# Altium schematic sheet Button.SchDoc(Button)
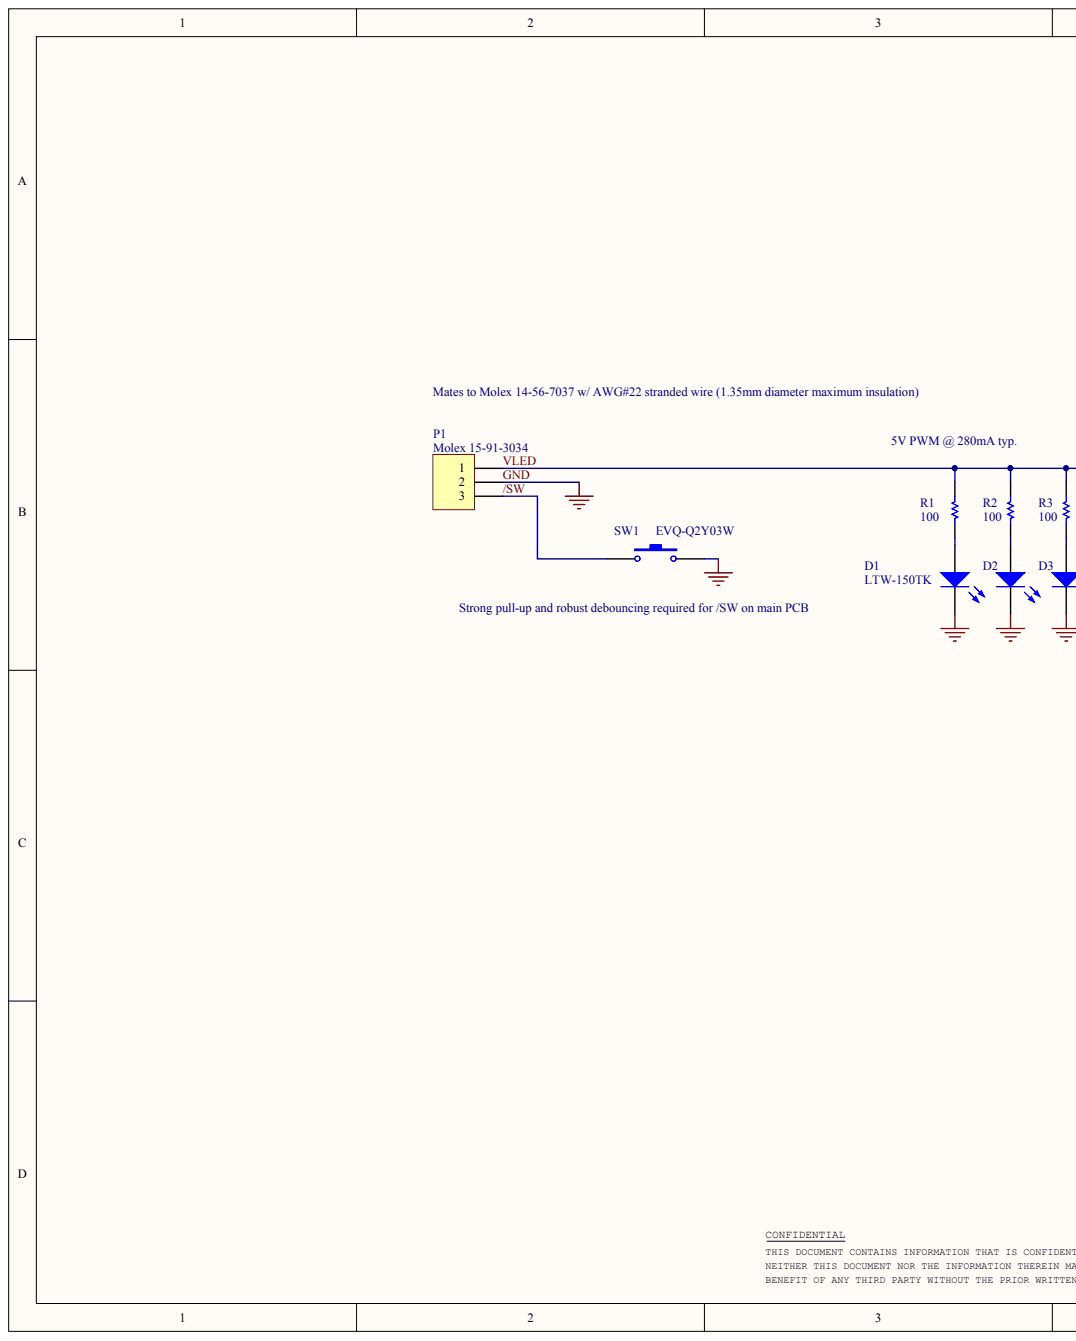
[diagram p0: Button.SchDoc, left]
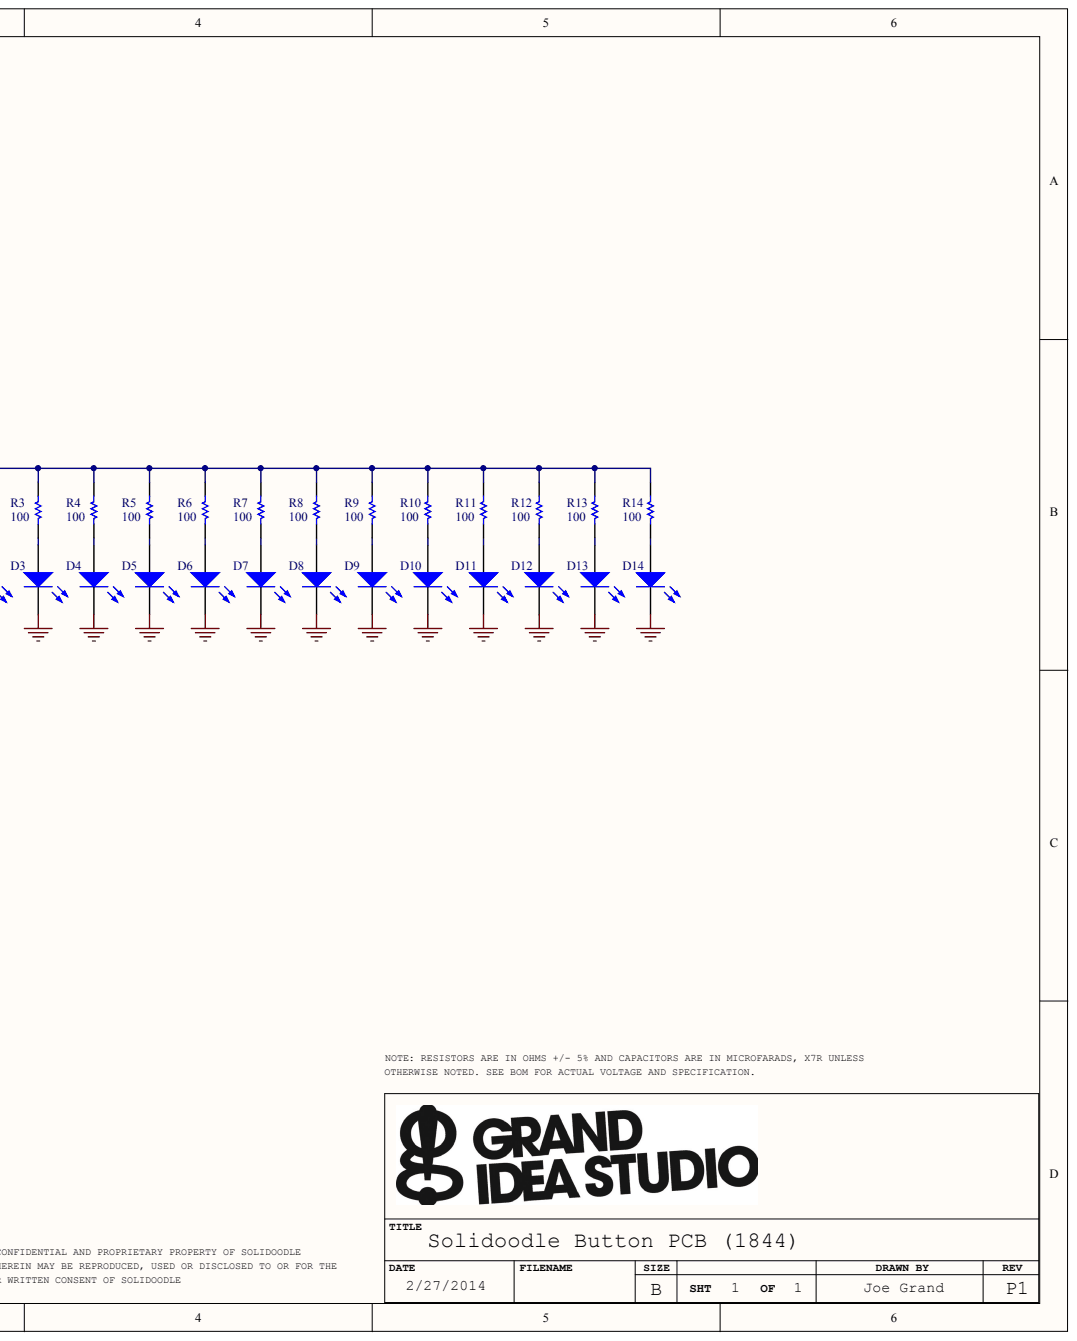
[diagram p1: Button.SchDoc, right]

## Parts
D1  LTW-150TK
D2  LTW-150TK
D3  LTW-150TK
D4  LTW-150TK
D5  LTW-150TK
D6  LTW-150TK
D7  LTW-150TK
D8  LTW-150TK
D9  LTW-150TK
D10  LTW-150TK
D11  LTW-150TK
D12  LTW-150TK
D13  LTW-150TK
D14  LTW-150TK
P1  Molex 15-91-3034
R1  value 100  (hidden comment: ERJ-6GEYJ101V)
R2  value 100  (hidden comment: ERJ-6GEYJ101V)
R3  value 100  (hidden comment: ERJ-6GEYJ101V)
R4  value 100  (hidden comment: ERJ-6GEYJ101V)
R5  value 100  (hidden comment: ERJ-6GEYJ101V)
R6  value 100  (hidden comment: ERJ-6GEYJ101V)
R7  value 100  (hidden comment: ERJ-6GEYJ101V)
R8  value 100  (hidden comment: ERJ-6GEYJ101V)
R9  value 100  (hidden comment: ERJ-6GEYJ101V)
R10  value 100  (hidden comment: ERJ-6GEYJ101V)
R11  value 100  (hidden comment: ERJ-6GEYJ101V)
R12  value 100  (hidden comment: ERJ-6GEYJ101V)
R13  value 100  (hidden comment: ERJ-6GEYJ101V)
R14  value 100  (hidden comment: ERJ-6GEYJ101V)
SW1  EVQ-Q2Y03W

## Nets
/SW: P1.3 SW1.2
GND: D1.2 D2.2 D3.2 D4.2 D5.2 D6.2 D7.2 D8.2 D9.2 D10.2 D11.2 D12.2 D13.2 D14.2 P1.2 SW1.1
VLED: P1.1 R1.1 R2.1 R3.1 R4.1 R5.1 R6.1 R7.1 R8.1 R9.1 R10.1 R11.1 R12.1 R13.1 R14.1
NetD1_1: D1.1 R1.2
NetD2_1: D2.1 R2.2
NetD3_1: D3.1 R3.2
NetD4_1: D4.1 R4.2
NetD5_1: D5.1 R5.2
NetD6_1: D6.1 R6.2
NetD7_1: D7.1 R7.2
NetD8_1: D8.1 R8.2
NetD9_1: D9.1 R9.2
NetD10_1: D10.1 R10.2
NetD11_1: D11.1 R11.2
NetD12_1: D12.1 R12.2
NetD13_1: D13.1 R13.2
NetD14_1: D14.1 R14.2

## Library data of the parts
D1-D14 P1 R1-R14 SW1 = (no symbol name): Publisher: Altium Limited
  D1-D14: Published: 8-Jun-2000; LatestRevisionDate: 17-Dec-2003; LatestRevisionNote: PCB Footprint replaced.; DatasheetVersion: 16-Apr-2002; PackageVersion: 16-Apr-2002; PackageReference: 3.5X2.8X1.9; PackageDescription: SM LED; 2 C-Bend Leads; Body 3.5 x 2.8 x 1.9 mm, inc leads (LxWxH typ)
  P1: LatestRevisionDate: 17-Jul-2002; LatestRevisionNote: Re-released for DXP Platform.
  R1-R14: Published: 8-Jun-2000; LatestRevisionDate: 17-Jul-2002; LatestRevisionNote: Re-released for DXP Platform.
  SW1: Published: 24-Mar-1999; LatestRevisionDate: 17-Jul-2002; LatestRevisionNote: Re-released for DXP Platform.; PackageReference: SPST-2
